# Altium schematic sheet Sheet2.SchDoc(Sheet2)
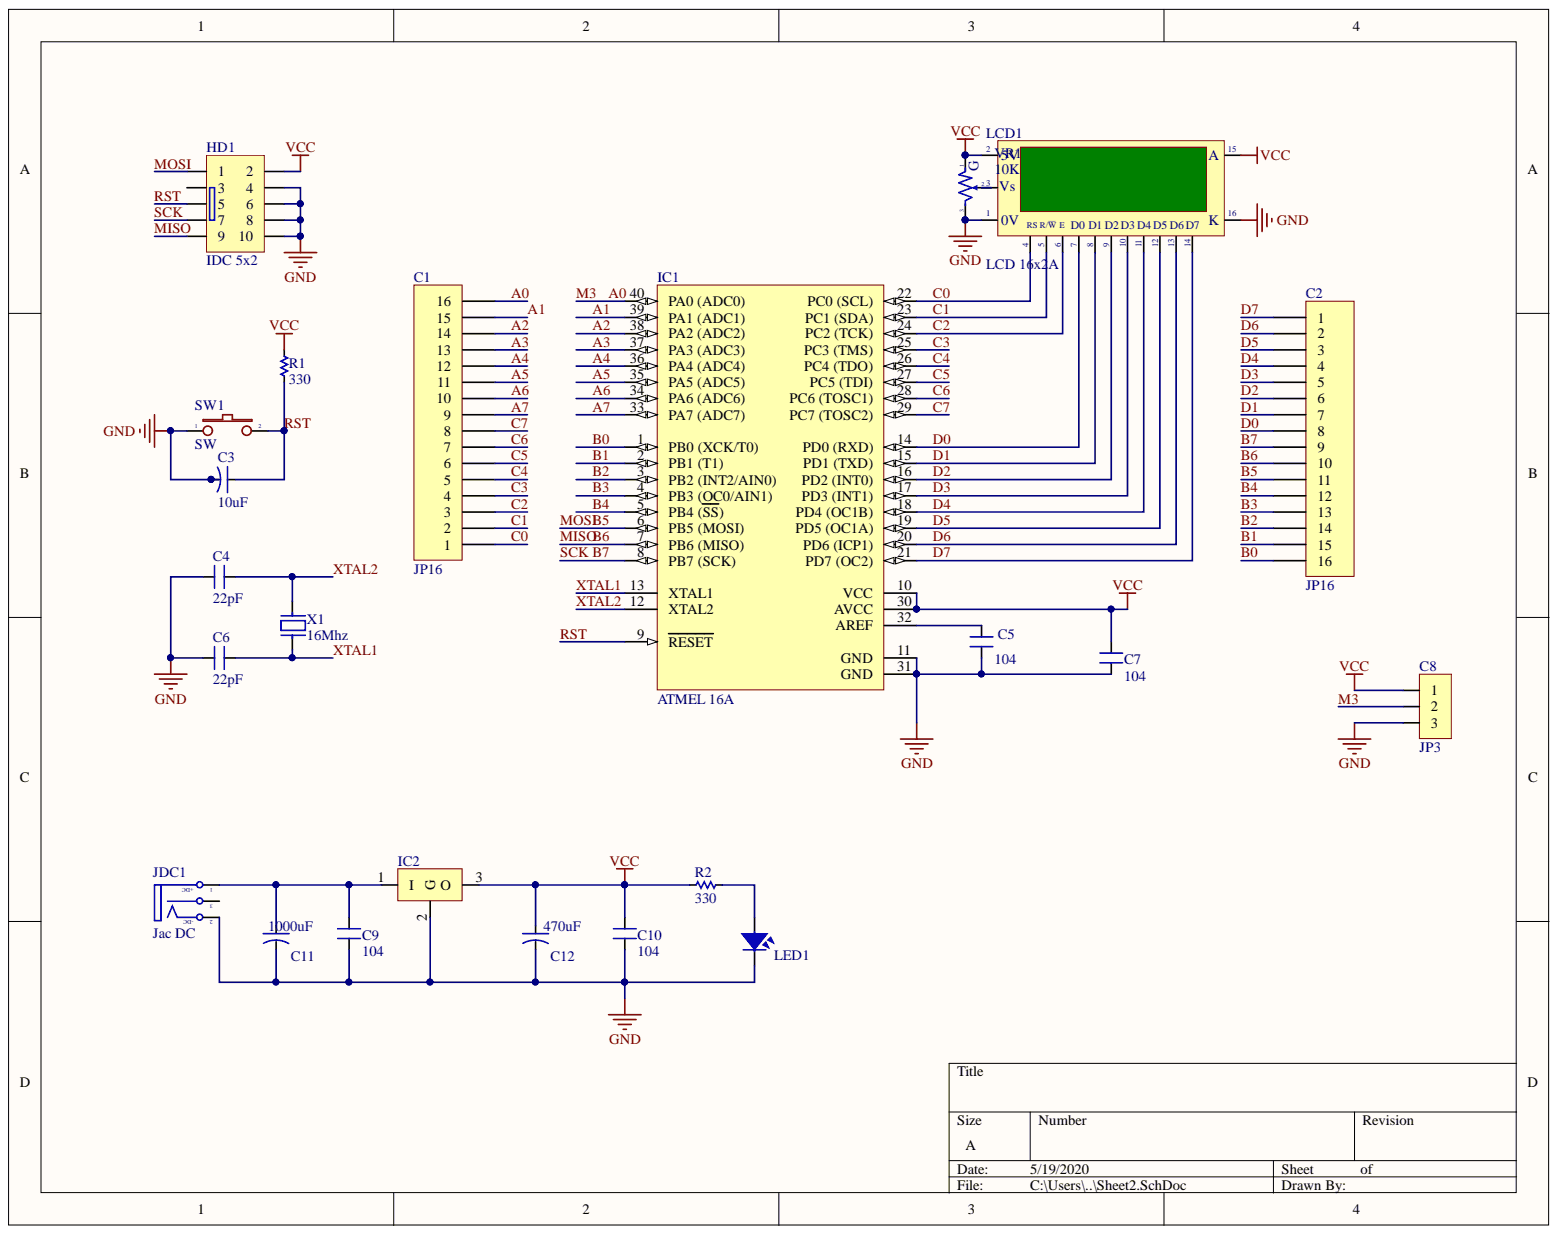
[diagram p0: Sheet2.SchDoc]

## Parts
C1  JP16  [JP16-C1T]
C2  JP16  [JP16-C1T]
C3  10uF  [CAP-ALU-SMD-4.5x5x1.4]
C4  22pF  [SM-CAP-0805]
C5  104  [SM-CAP-0805]
C6  22pF  [SM-CAP-0805]
C7  104  [SM-CAP-0805]
C8  JP3  [JP3-C1T]
C9  104  [SM-CAP-0805]
C10  104  [SM-CAP-0805]
C11  1000uF  [CAP-ALU-SMD-4.5x5x1.4]
C12  470uF  [CAP-ALU-SMD-4.5x5x1.4]
HD1  IDC 5x2  [IDC10]
IC1  ATMEL 16A  [DIP40/W0.6]
IC2  LM78xx  [SOT89-321]  (value not drawn)
JDC1  Jac DC  [DC0]
LCD1  LCD 16x2A  [LCD16X2N]
LED1  LED  [LED-0805-Red]
R1  330  [RES-SMD-0805]
R2  330  [RES-SMD-0805]
SW1  SW  [SW1-SMD]
VR1  10K  [VR-S2]
X1  16Mhz  [XT2]

## Nets
GND: C3.2 C4.1 C5.1 C6.1 C7.1 C8.3 C9.1 C10.1 C11.2 C12.2 HD1.4 HD1.6 HD1.8 HD1.10 IC1.11(GND) IC1.31(GND) IC2.2 JDC1.2 LCD1.1(0V) LCD1.16(K) LED1.2 SW1.1 VR1.3
VCC: C7.2 C8.1 C10.2 C12.1 HD1.2 IC1.10(VCC) IC1.30(AVCC) IC2.3 LCD1.2(VR1) LCD1.15(A) R1.1 R2.2 VR1.1
A0: C1.16 C8.2 IC1.40(PA0_(ADC0))
A1: C1.15 IC1.39(PA1_(ADC1))
A2: C1.14 IC1.38(PA2_(ADC2))
A3: C1.13 IC1.37(PA3_(ADC3))
A4: C1.12 IC1.36(PA4_(ADC4))
A5: C1.11 IC1.35(PA5_(ADC5))
A6: C1.10 IC1.34(PA6_(ADC6))
A7: C1.9 IC1.33(PA7_(ADC7))
B0: C2.16 IC1.1(PB0_(XCK/T0))
B1: C2.15 IC1.2(PB1_(T1))
B2: C2.14 IC1.3(PB2_(INT2/AIN0))
B3: C2.13 IC1.4(PB3_(OC0/AIN1))
B4: C2.12 IC1.5(PB4_(SS))
B5: C2.11 HD1.1 IC1.6(PB5_(MOSI))
B6: C2.10 HD1.9 IC1.7(PB6_(MISO))
B7: C2.9 HD1.7 IC1.8(PB7_(SCK))
C0: C1.1 IC1.22(PC0_(SCL)) LCD1.4(RS)
C1: C1.2 IC1.23(PC1_(SDA)) LCD1.5
C2: C1.3 IC1.24(PC2_(TCK)) LCD1.6(E)
C3: C1.4 IC1.25(PC3_(TMS))
C4: C1.5 IC1.26(PC4_(TDO))
C5: C1.6 IC1.27(PC5_(TDI))
C6: C1.7 IC1.28(PC6_(TOSC1))
C7: C1.8 IC1.29(PC7_(TOSC2))
D0: C2.8 IC1.14(PD0_(RXD)) LCD1.7(D0)
D1: C2.7 IC1.15(PD1_(TXD)) LCD1.8(D1)
D2: C2.6 IC1.16(PD2_(INT0)) LCD1.9(D2)
D3: C2.5 IC1.17(PD3_(INT1)) LCD1.10(D3)
D4: C2.4 IC1.18(PD4_(OC1B)) LCD1.11(D4)
D5: C2.3 IC1.19(PD5_(OC1A)) LCD1.12(D5)
D6: C2.2 IC1.20(PD6_(ICP1)) LCD1.13(D6)
D7: C2.1 IC1.21(PD7_(OC2)) LCD1.14(D7)
RST: C3.1 HD1.5 IC1.9(RESET) R1.2 SW1.2
XTAL1: C6.2 IC1.13(XTAL1) X1.1
XTAL2: C4.2 IC1.12(XTAL2) X1.2
NetC5_2: C5.2 IC1.32(AREF)
NetC9_2: C9.2 C11.1 IC2.1 JDC1.1
NetLCD1_3: LCD1.3(Vs) VR1.2
NetLED1_1: LED1.1 R2.1

Not connected: HD1 3; JDC1 3

## Library data of the parts
C1 C2 = JP16: WR-PHD 2.54 mm Angled Socket Header; partNo: 613016143121; Manufacturer: W¨¹rth Elektronik eiSos GmbH; NoPins: 16; ComponentLink2 Description: Datasheet; ComponentLink1 Description: Manufacturer; Created Date: 29 March 2013; ComponentLink2URL: http://katalog.we-online.de/em/datasheet/6130xx143121.pdf; ComponentLink1URL: http://www.we-online.com; Component Kind: Standard; Library Name: LIBRARYBLK.IntLib; Created by: Karrer Zheng
C3 C11 C12 = CN-ALU-SMD: Polarized Capacitor (Axial); Published: 8-Jun-2000; PackageReference: POLAR0.8; LatestRevisionNote: Re-released for DXP Platform.; LatestRevisionDate: 17-Jul-2002; Component Kind: Standard; Publisher: Altium Limited; Library Name: LIBRARYBLK.IntLib
C4-C7 C9 C10 = CN: Value: 0,1uF/300V; Designed by: VanDungEvn; Component Kind: Standard; Library Name: syhaunguyen.IntLib
C8 = JP3: WR-PHD 2.54 mm Angled Socket Header; partNo: 613003143121; Manufacturer: W¨¹rth Elektronik eiSos GmbH; NoPins: 03; ComponentLink2 Description: Datasheet; ComponentLink1 Description: Manufacturer; Created Date: 29 March 2013; ComponentLink2URL: http://katalog.we-online.de/em/datasheet/6130xx143121.pdf; ComponentLink1URL: http://www.we-online.com; Component Kind: Standard; Library Name: LIBRARYBLK.IntLib; Created by: Karrer Zheng
HD1 = IDC 5X2: Value: Header 5x2; Component Kind: Standard; Library Name: LIBRARYBLK.IntLib
IC1 = ATmega16A-PU: 8-Bit AVR Microcontroller with 16K Bytes of In-System Programmable Flash Memory; Value: Atmega 16L; Component Kind: Standard; Library Name: LIBRARYBLK.IntLib
IC2 = LM78xx: Positive Voltage Regulator; Note: Tab is connected to pin 3 (GND).; Published: 24-Aug-2001; PackageVersion: Jul-1998; PackageReference: ISOWATT220AB; PackageDescription: TO, Thru-Hole, Vertical, Heatsink Mounted; 3 In-Line Leads; Pitch 2.54 mm; Pin sequence 1, 3, 2; LatestRevisionNote: Re-released for DXP Platform.; LatestRevisionDate: 17-Jul-2002; DatasheetVersion: Apr-1994; ComponentLink2URL: http://www.st.com/stonline/products/literature/ds/2146/l78m05c.pdf; ComponentLink2Description: Datasheet; ComponentLink1URL: http://www.st.com/; ComponentLink1Description: Manufacturer Link; Component Kind: Standard; Publisher: Altium Limited; Library Name: LIBRARY damedientu.IntLib
JDC1 = DCIN: Jac DC input; Value: Jac DC; Component Kind: Standard; Library Name: LIBRARYBLK.IntLib
LCD1 = LCD16x2A: LCD dipslay 16 char, 2 line; Value: LCD16x2A; Component Kind: Standard; Library Name: LIBRARYBLK.IntLib
LED1 = LED SMD: Value: LED 3 - 5 ly 2 Colour; Component Kind: Standard; Library Name: LIBRARYBLK.IntLib
R1 R2 = Res-SMD: Resistor; Manufacturer: Generic Components; Class: Passive; Published: 08/06/2000; Component Kind: Standard; Publisher: Altium Hobart Technology Centre
  R1: Library Name: LIBRARYBLK.IntLib
  R2: Library Name: LIBRARY damedientu.IntLib
SW1 = SW2: Switch button 2 pin; Value: SW chip 2 pin; Component Kind: Standard; Library Name: LIBRARYBLK.IntLib
VR1 = VR-S: Var Resistor; Value: Volume 50k; Parameter 1: Volume 100k; Component Kind: Standard; Library Name: LIBRARYBLK.IntLib
X1 = XT2: Crystal, 32.768 KHz, -40 to 85 degC, 3.2 x 1.5 x 0.9 mm SMD; Value: 4Mhz; Component Kind: Standard; Library Name: LIBRARY damedientu.IntLib
